# Altium schematic sheet CH347.SchDoc(CH347)
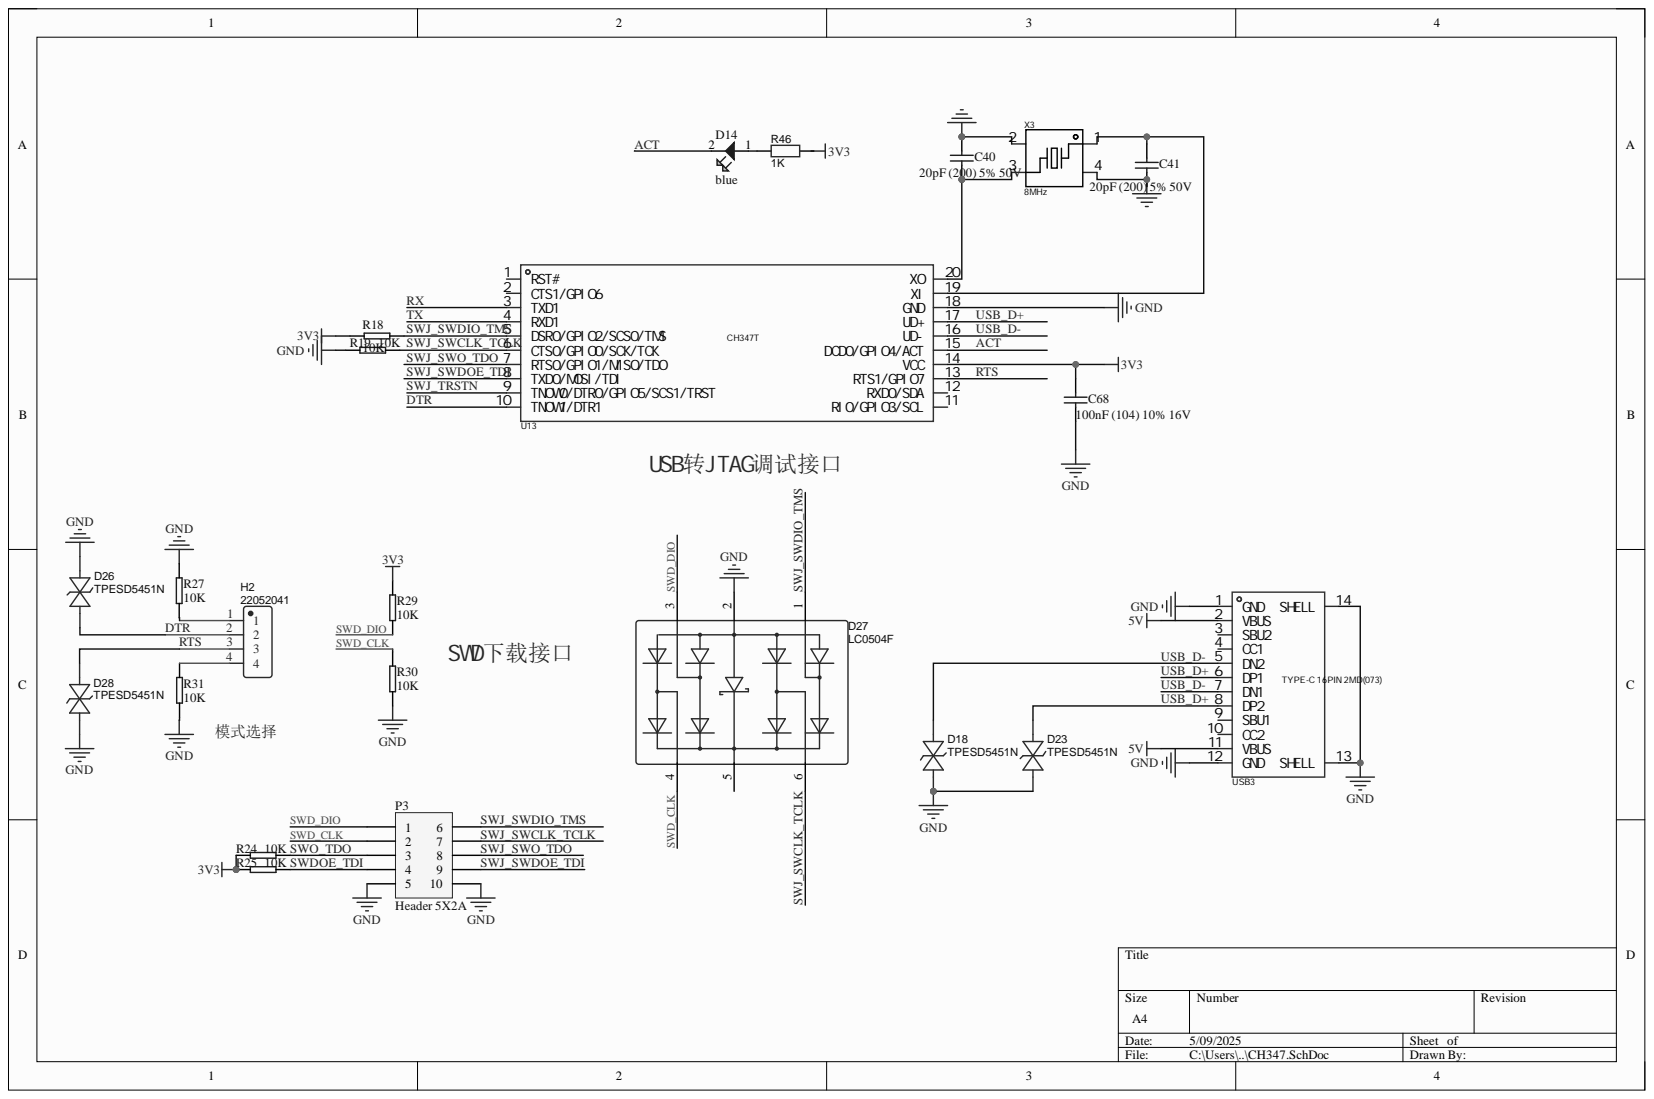
[diagram p0: CH347.SchDoc]

## Parts
C40  20pF (200) 5% 50V  [0402_C]
C41  20pF (200) 5% 50V  [0402_C]
C68  100nF (104) 10% 16V  [0402_C]
D14  blue  [LED0603-RD]
D18  TPESD5451N  [DFN1006-2L-BI]
D23  TPESD5451N  [DFN1006-2L-BI]
D26  TPESD5451N  [DFN1006-2L-BI]
D27  LC0504F  [ESLC050SOT-363_L2.0-W1.3-P0.65-LS2.1-BR]
D28  TPESD5451N  [DFN1006-2L-BI]
H2  22052041  [HDR-TH_4P-P2.54-V-M]
P3  Header 5X2A  [HDR-TH_10P-P2.54-V-M-R2-C5-S2.54]
R18  10K  [R0603]
R19  10K  [R0603]
R24  10K  [R0603]
R25  10K  [R0603]
R27  10K  [R0603]
R29  10K  [R0603]
R30  10K  [R0603]
R31  10K  [R0603]
R46  1K  [R0603]
U13  CH347T  [TSSOP-20_L6.5-W4.4-P0.65-LS6.4-BL]
USB3  TYPE-C 16PIN 2MD(073)  [USB-C-SMD_TYPE-C-6PIN-2MD-073]
X3  8MHz  External load capacitor: 20pF  [CRYSTAL-SMD_4P-L3.2-W2.5-BL]

## Nets
3V3: C68.2 R18.2 R24.1 R25.1 R29.2 U13.14(VCC)
5V: USB3.2(VBUS) USB3.11(VBUS)
GND: C40.2 C41.1 C68.1 D18.1 D23.2 D26.1 D27.2 D28.1 P3.5 P3.10 R19.2 R27.1 R30.1 R31.2 U13.18(GND) USB3.1(GND) USB3.12(GND) USB3.13(SHELL) USB3.14(SHELL) X3.2 X3.4
ACT: D14.2 U13.15(DCD0/GPIO4/ACT)
DTR: D26.2 H2.2 U13.10(TNOW1/DTR1)
RTS: D28.2 H2.3 U13.13(RTS1/GPIO7)
RX: U13.3(TXD1)
SWD_CLK: D27.4 P3.2 R30.2
SWD_DIO: D27.3 P3.1 R29.1
SWDOE_TDI: P3.4 R25.2
SWJ_SWCLK_TCLK: D27.6 P3.7 R19.1 U13.6(CTS0/GPIO0/SCK/TCK)
SWJ_SWDIO_TMS: D27.1 P3.6 R18.1 U13.5(DSR0/GPIO2/SCS0/TMS)
SWJ_SWDOE_TDI: P3.9 U13.8(TXD0/MOSI/TDI)
SWJ_SWO_TDO: P3.8 U13.7(RTS0/GPIO1/MISO/TDO)
SWJ_TRSTN: U13.9(TNOW0/DTR0/GPIO5/SCS1/TRST)
SWO_TDO: P3.3 R24.2
TX: U13.4(RXD1)
USB_D+: D23.1 U13.17(UD+) USB3.6(DP1) USB3.8(DP2)
USB_D-: D18.2 U13.16(UD-) USB3.5(DN2) USB3.7(DN1)
NetC40_1: C40.1 U13.20(XO) X3.3
NetC41_2: C41.2 U13.19(XI) X3.1
NetD14_1: D14.1 R46.2
NetH2_1: H2.1 R27.2
NetH2_4: H2.4 R31.1

Not connected: D27 5; R46 1; U13 1(RST#) 2(CTS1/GPIO6) 11(RI0/GPIO3/SCL) 12(RXD0/SDA); USB3 3(SBU2) 4(CC1) 9(SBU1) 10(CC2)

## Library data of the parts
C40 C41 = 0402 20pF (200) 5% 50V: 贴片电容; Component Kind: Standard; ComponentLink1Description: 供应商链接; ComponentLink1URL: http://www.szlcsc.com/product/details_1906.html; Library Name: Miscellaneous Devices LC.IntLib; Package: 0402; Supplier: LC; SuppliersPartNumber: C1554
C68 = 0402 100nF (104) 10% 16V: 贴片电容; Component Kind: Standard; ComponentLink1Description: 供应商链接; ComponentLink1URL: http://www.szlcsc.com/product/details_1877.html; Library Name: Miscellaneous Devices LC.IntLib; Package: 0402; Supplier: LC; SuppliersPartNumber: C1525
D14 = LED-贴片: Component Kind: Standard; Library Name: CZ 3D.IntLib
D18 D23 D26 D28 = TPESD5451N: Manufacturer: TECH PUBLIC(台舟); Contributor: LCSC; Component Kind: Standard; JLCPCB Part Class: Extended Part; link: https://so.szlcsc.com/global.html?k=C970008&ref=editor; Manufacturer Part: TPESD5451N; spicePre: D; spiceSymbolName: TPESD5451N; Supplier: LCSC; Supplier Part: C970008
D27 = LC0504F: Manufacturer: Leiditech(雷卯电子); Contributor: LCSC; Component Kind: Standard; JLCPCB Part Class: Extended Part; Manufacturer Part: LC0504F; spicePre: D; spiceSymbolName: LC0504F; Supplier: LCSC; Supplier Part: C490608
H2 = 22052041: Manufacturer: MOLEX; Contributor: LCSC; Component Kind: Standard; Manufacturer Part: 22052041; spicePre: H; spiceSymbolName: 22052041; Supplier: LCSC; Supplier Part: C586987
P3 = Header 5X2A: Header, 5-Pin, Dual row; Component Kind: Standard; LatestRevisionDate: 17-Jul-2002; LatestRevisionNote: Re-released for DXP Platform.; Library Name: Miscellaneous Connectors.IntLib; Signal Integrity: Connector; Publisher: Altium Limited
R18 R19 R24 R25 R27 R29-R31 = Res: Component Kind: Standard; Library Name: CZ 3D.IntLib
R46 = 1K: Manufacturer: UniOhm; Manufacturer Part: 0603WAF1001T5E; nameAlias: Resistance (Ohms); Component Kind: Standard; LCSC Assembly: Yes; spicePre: R; spiceSymbolName: 0603WAF1001T5E; Supplier: LCSC; Supplier Part: C21190
U13 = CH347T: Manufacturer: WCH(南京沁恒); Add into BOM: yes; Applications Function: USB转UART；USB转SPI；USB转I2C；USB转JTAG; Data Rate: 9Mbps; Number of Channels: 2; Protocol: USB 2.0; Supply Voltage: 3.6V；3V；3.3V; Component Kind: Standard; Convert to PCB: yes; Datasheet: https://atta.szlcsc.com/upload/public/pdf/source/20240313/6D00AFFDD61A30A4DD0241161AD59922.pdf; Device: CH347T; JLCPCB Part Class: Extended Part; LCSC Part Name: 高速USB转接芯片CH347; Library Name: Schlib1.SchLib; Manufacturer Part: CH347T; Operating Temperature: -40℃~+85℃; PCB3D: TSSOP-20_L6.5-W4.4-H1.0-LS6.4-P0.65; Supplier: LCSC; Supplier Footprint: TSSOP-20; Supplier Part: C5122332; Symbol: CH347T
USB3 = TYPE-C 16PIN 2MD(073): Manufacturer: SHOU HAN(首韩); Add into BOM: yes; Connect-Disconnect Life: 5千次; Core Colour: 黑色; Current Rating - Power (Max): 5A; Gender: 母; Number of Contacts: 16P; Number of Ports: 1; Rated Voltage (Max): 5V; Component Kind: Standard; Connector Type: Type-C; Convert to PCB: yes; Datasheet: https://atta.szlcsc.com/upload/public/pdf/source/20211123/39B17C16241EAC15C5E277BDA434118E.pdf; Device: TYPE-C 16PIN 2MD(073); JLCPCB Part Class: Extended Part; LCSC Part Name: Type-C 母 卧贴; Library Name: Schlib1.SchLib; Manufacturer Part: TYPE-C 16PIN 2MD(073); Mounting Style: 卧贴; Operating Temperature Range: -25℃~+85℃; PCB3D: USB-C-SMD_TYPE-C-6PIN-2MD-073; Standard: USB 3.1; Supplier: LCSC; Supplier Footprint: SMD; Supplier Part: C2765186; Symbol: TYPE-C 16PIN 2MD(073)
X3 = X32258MSB4SI: Manufacturer: YXC(扬兴晶振); Add into BOM: yes; Crystal Type: 贴片晶振; Frequency Stability(Full temperature range): ±20ppm; Normal temperature Frequency Tolerance: ±10ppm; Component Kind: Standard; Convert to PCB: yes; Datasheet: https://atta.szlcsc.com/upload/public/pdf/source/20240329/19D5F850207469550BEB00D7DFEDBC7A.pdf; Device: X32258MSB4SI; JLCPCB Part Class: Extended Part; LCSC Part Name: 8MHz ±10ppm 20pF; Manufacturer Part: X32258MSB4SI; Operating Temperature: -40℃~+85℃; PCB3D: OSC-SMD_4P-L3.2-W2.5-H1.0; Supplier: LCSC; Supplier Footprint: SMD3225-4P; Supplier Part: C2682774; Symbol: X32258MSB4SI; Value: 8MHz
